# Altium schematic sheet Microcontroller.SchDoc
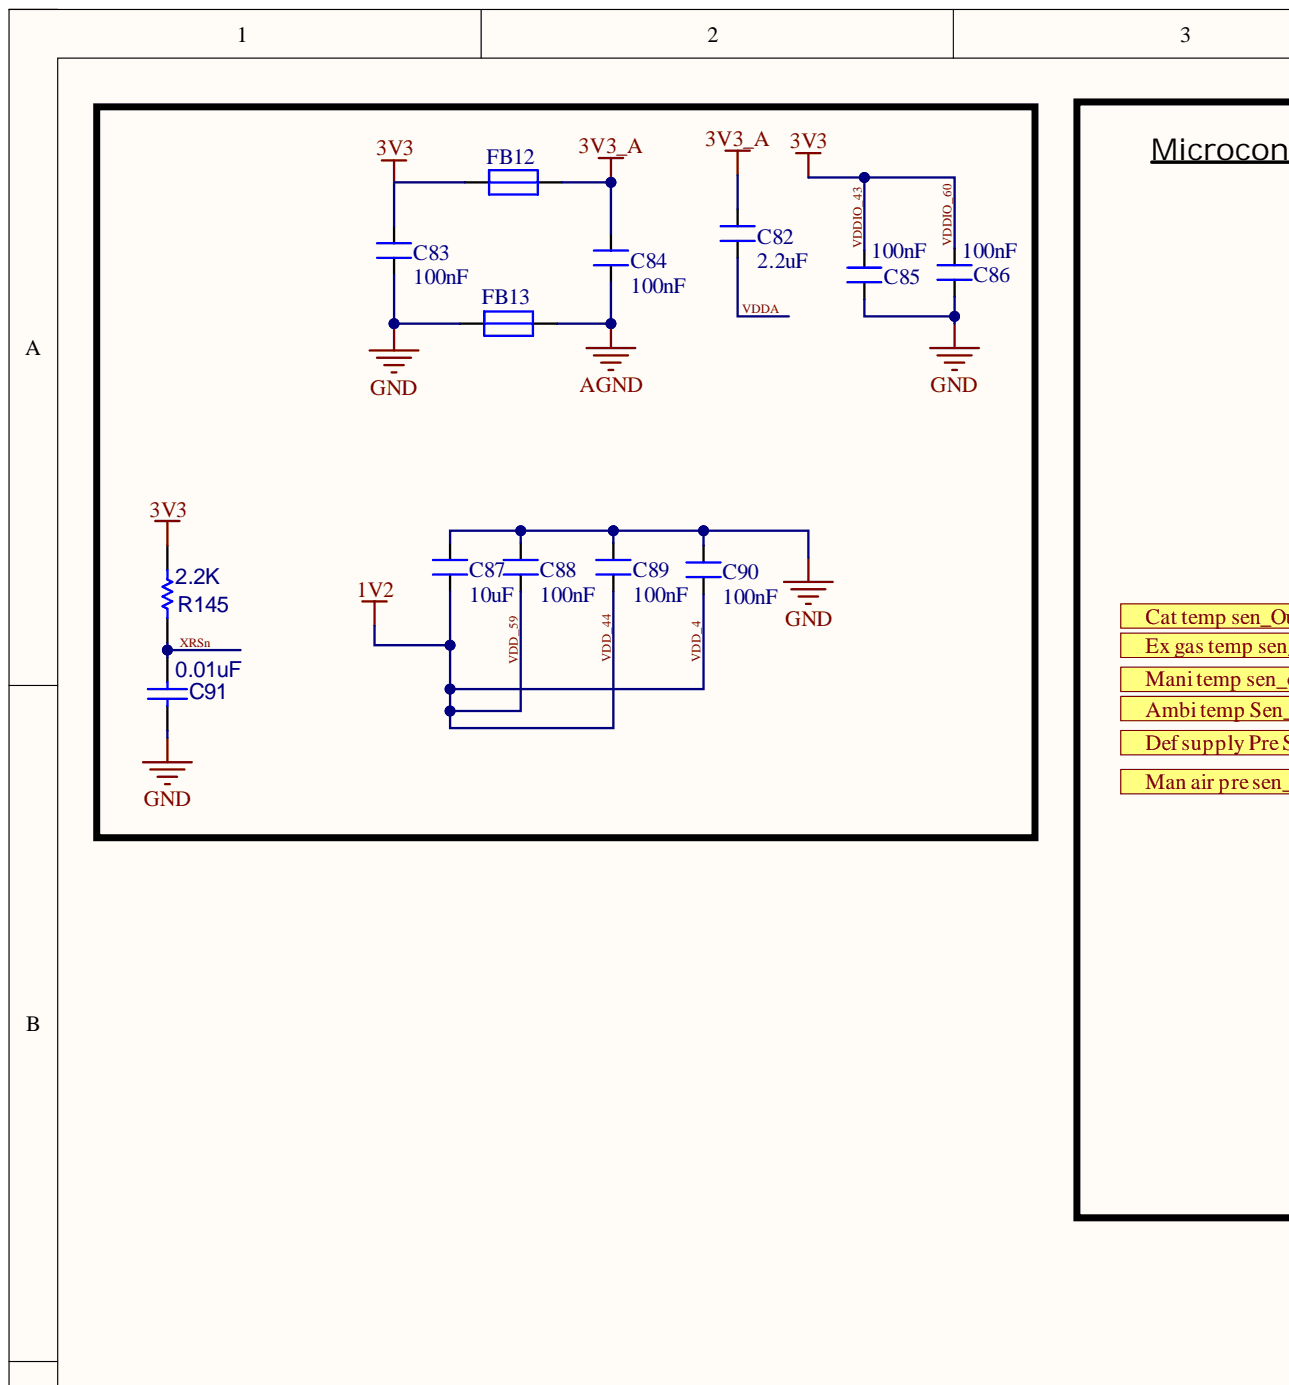
[diagram p0: Microcontroller.SchDoc, top left]
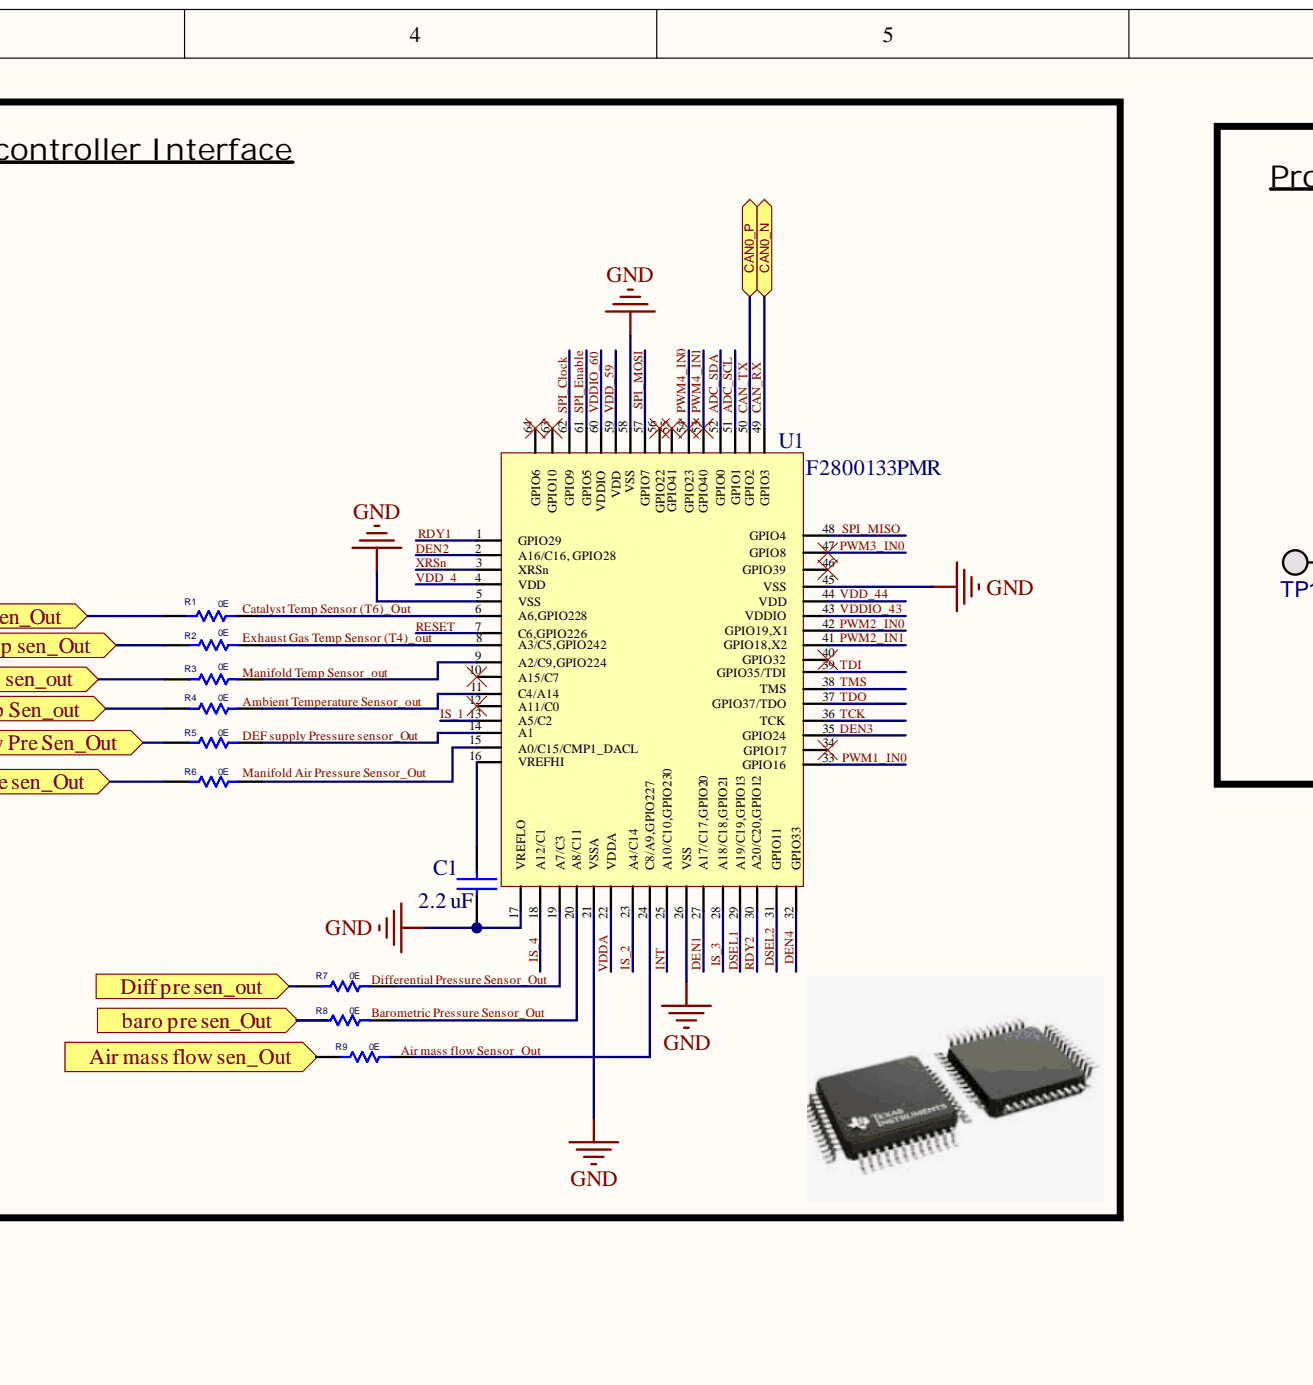
[diagram p1: Microcontroller.SchDoc, top centre]
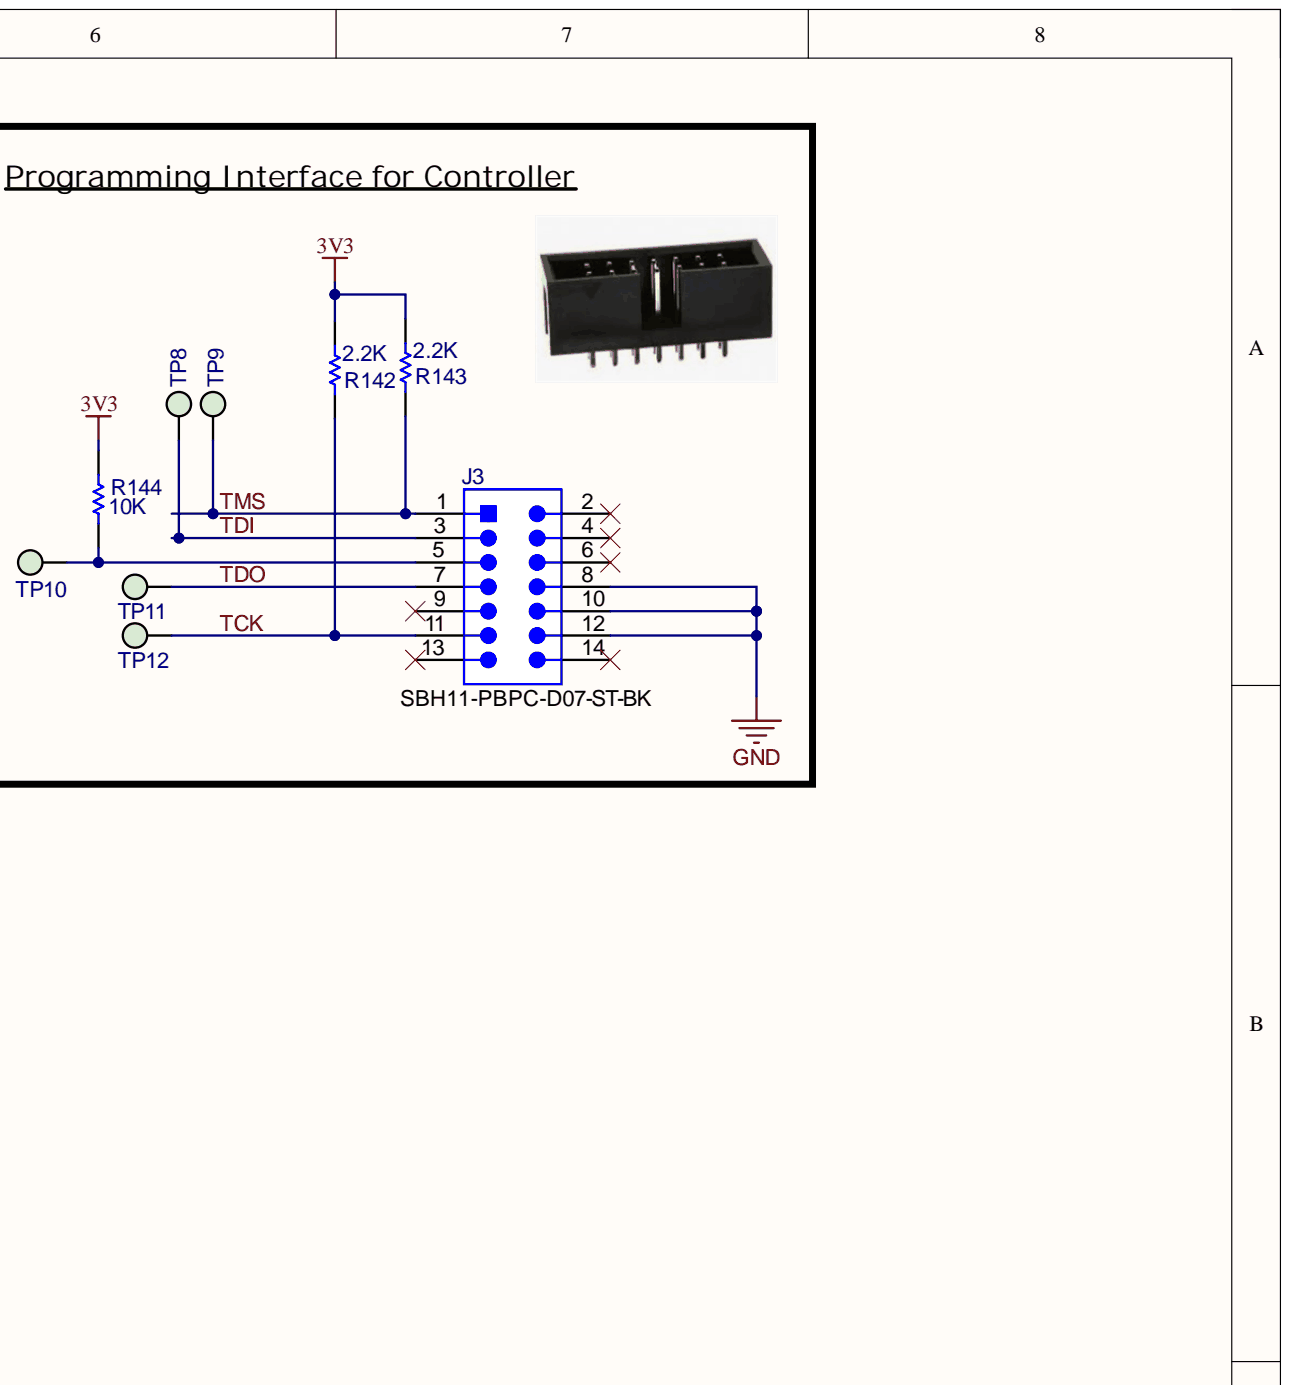
[diagram p2: Microcontroller.SchDoc, top right]
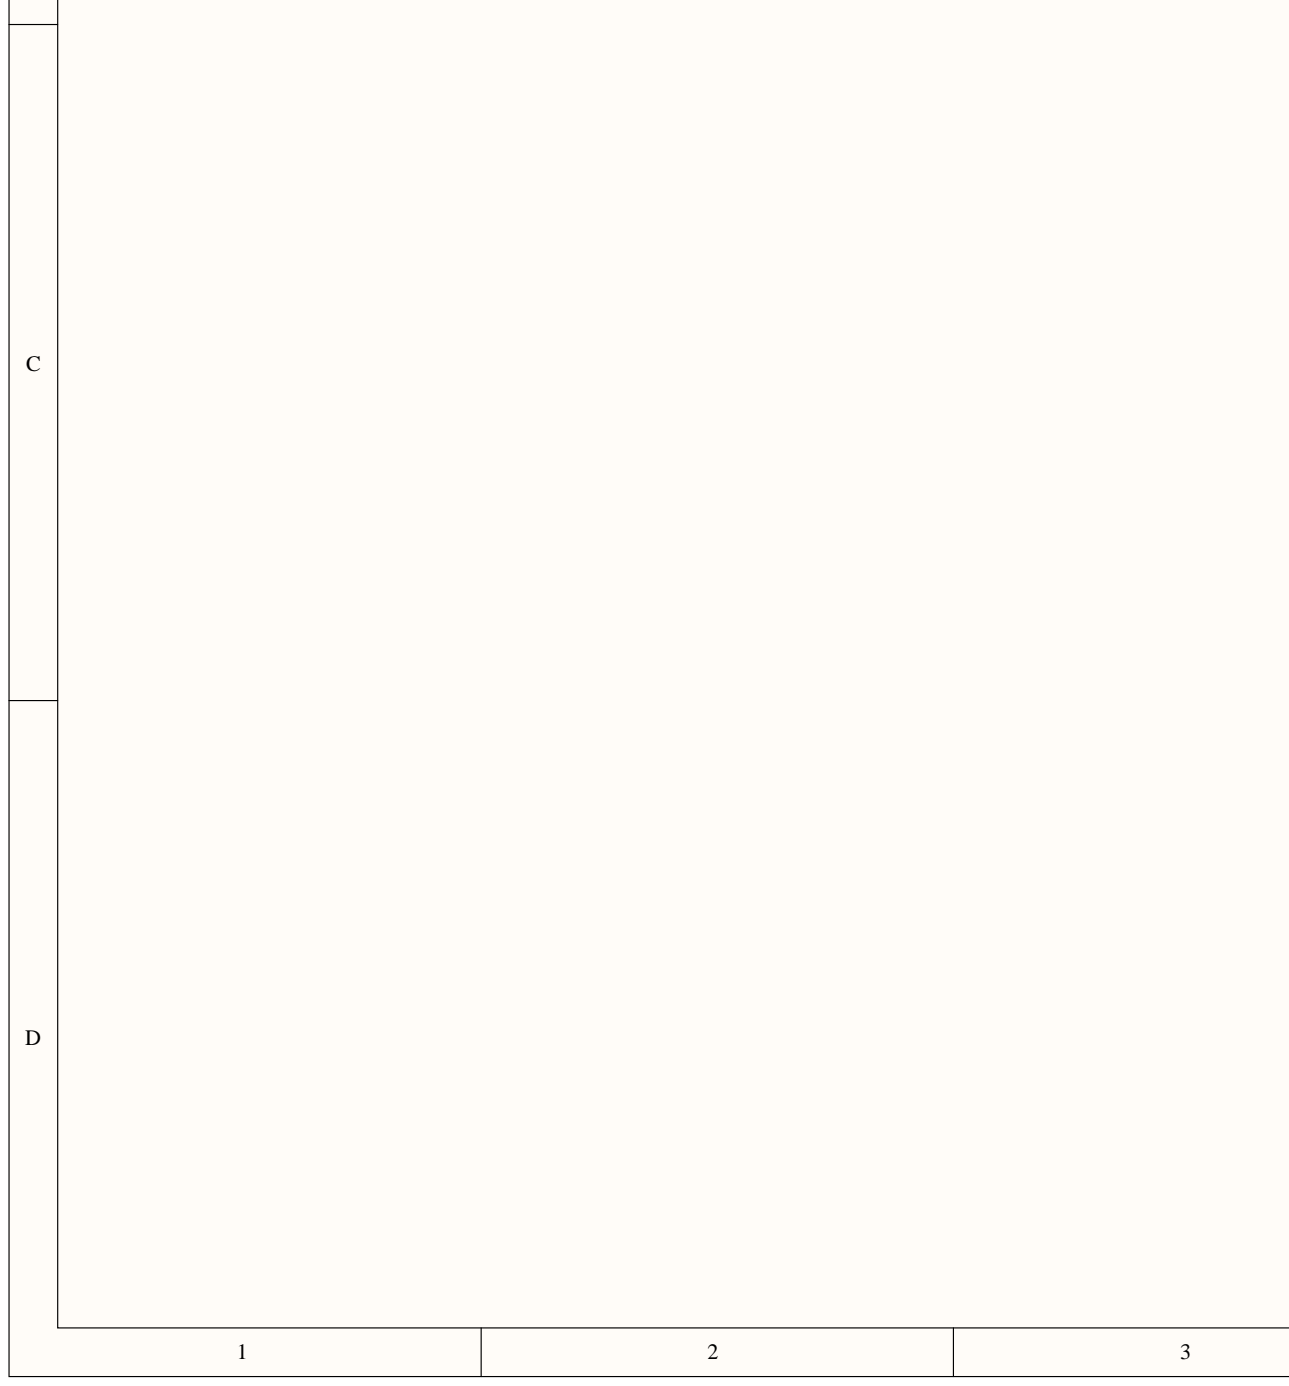
[diagram p3: Microcontroller.SchDoc, bottom left]
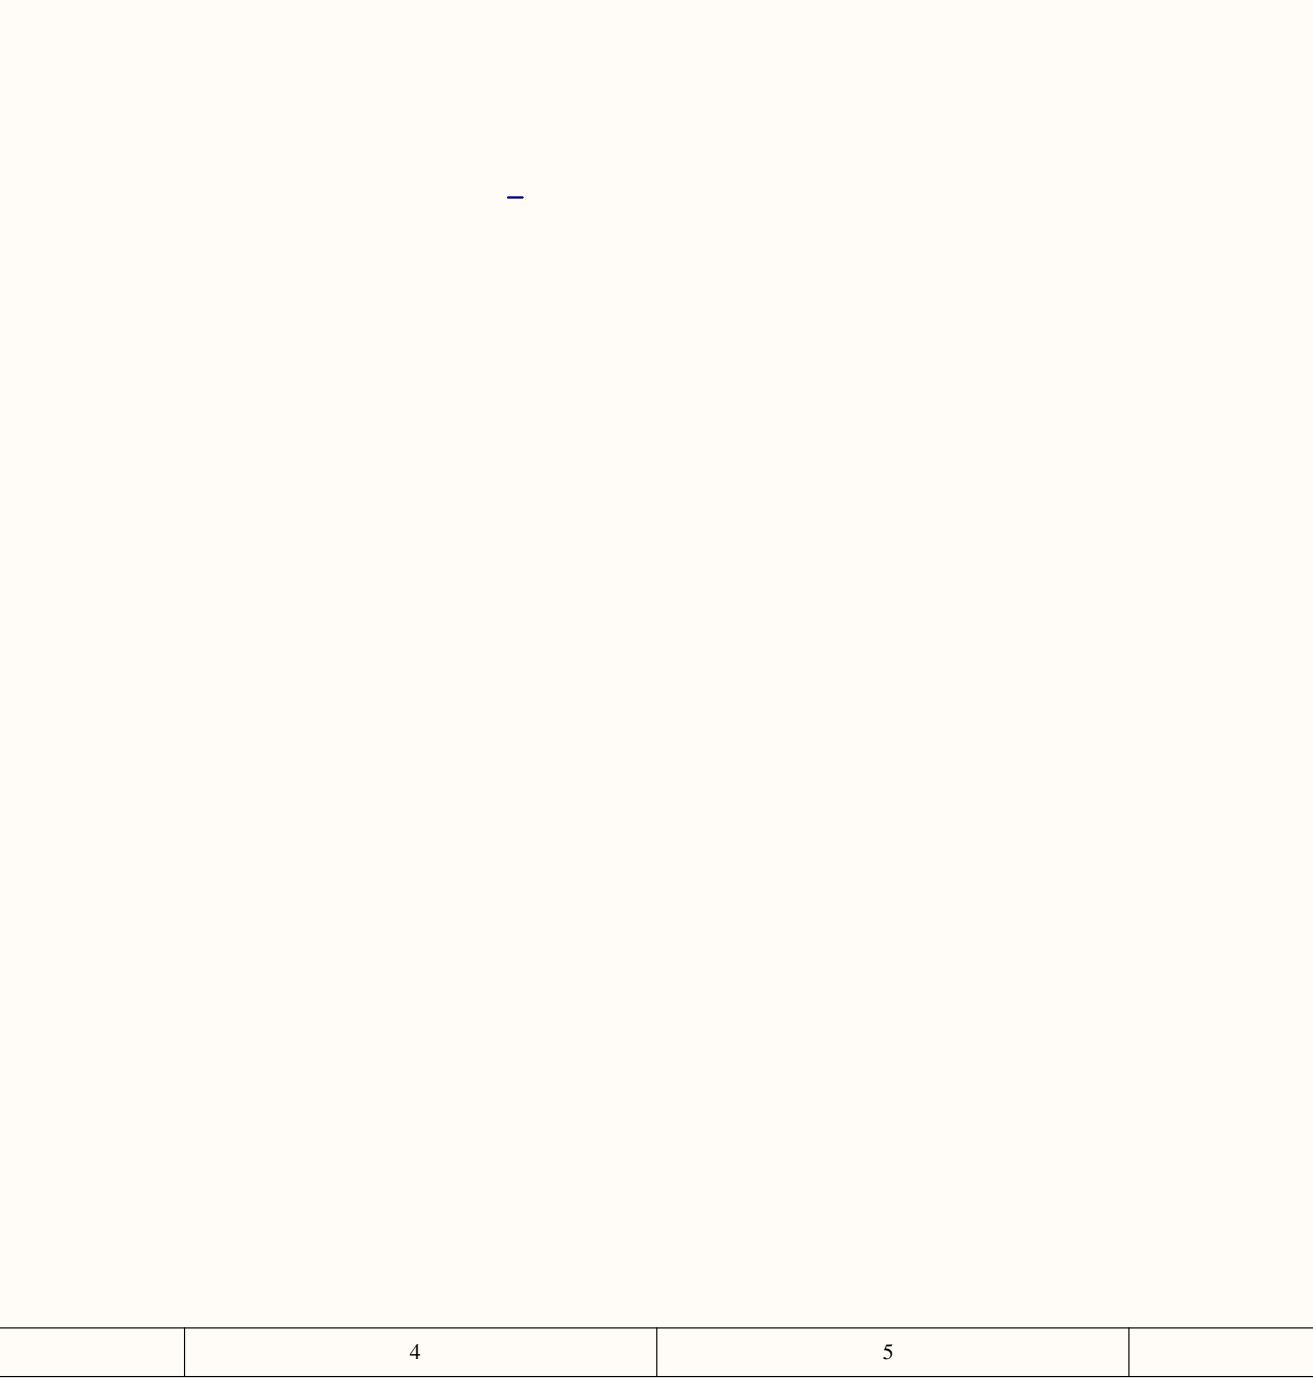
[diagram p4: Microcontroller.SchDoc, bottom centre]
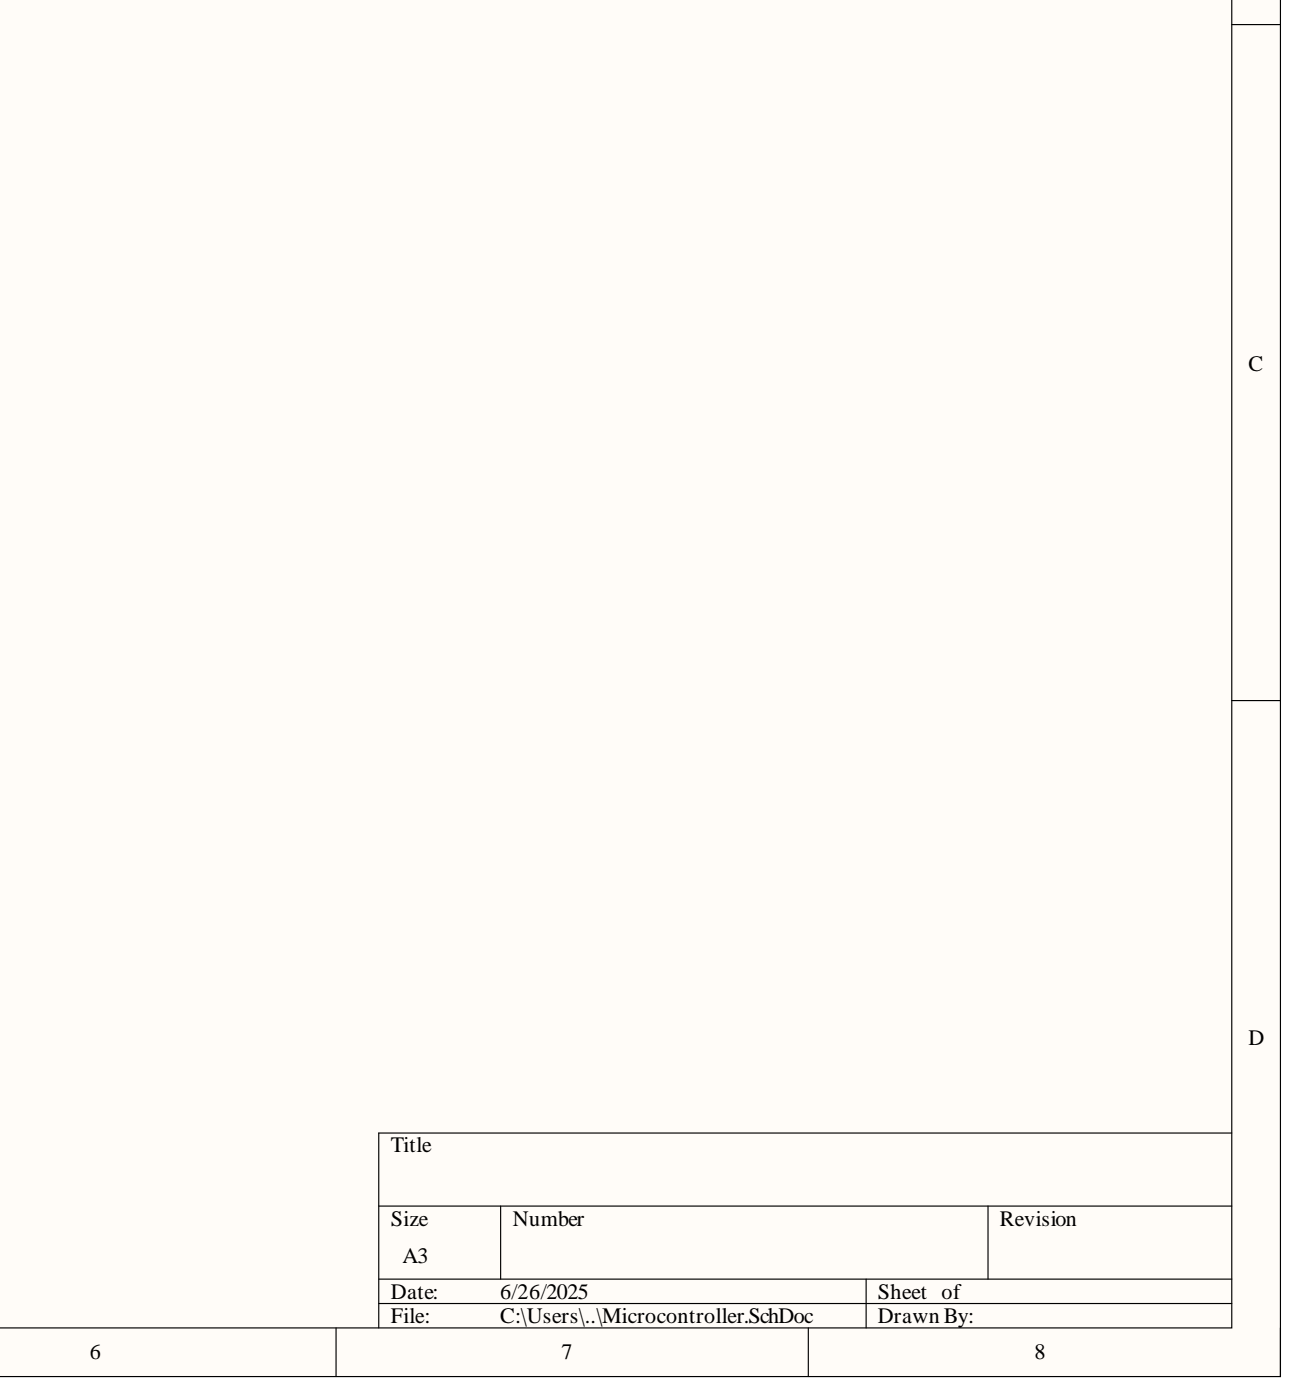
[diagram p5: Microcontroller.SchDoc, bottom right]

## Parts
C1  2.2 uF  [CAP-0805]
C82  2.2uF  [CAP_0603]
C83  100nF  [CAP_0201]
C84  100nF  [CAP_0201]
C85  100nF  [CAP_0402]
C86  100nF  [CAP_0402]
C87  10uF  [CAP_0603]
C88  100nF  [CAP_0402]
C89  100nF  [CAP_0402]
C90  100nF  [CAP_0402]
C91  0.01uF  [CAP-0603]
FB12  MPZ1608S101ATAH0  [RES_0603]  (value not drawn)
FB13  MPZ1608S101ATAH0  [RES_0603]  (value not drawn)
J3  SBH11-PBPC-D07-ST-BK  [3M_N2514-6002-RB]
R1  0E  [RES-0603]
R2  0E  [RES-0603]
R3  0E  [RES-0603]
R4  0E  [RES-0603]
R5  0E  [RES-0603]
R6  0E  [RES-0603]
R7  0E  [RES-0603]
R8  0E  [RES-0603]
R9  0E  [RES-0603]
R142  2.2K  [RES-0603]
R143  2.2K  [RES-0603]
R144  10K  [RES-0603]
R145  2.2K  [RES-0603]
TP8  Test Point  [TestPoint]  (value not drawn)
TP9  Test Point  [TestPoint]  (value not drawn)
TP10  Test Point  [TestPoint]  (value not drawn)
TP11  Test Point  [TestPoint]  (value not drawn)
TP12  Test Point  [TestPoint]  (value not drawn)
U1  F2800133PMR  [F2800133PMR(1)]

## Ports
Air mass flow sen_Out, Ambi temp Sen_out, baro pre sen_Out, CAN0_N, CAN0_P, Cat temp sen_Out, Def supply Pre Sen_Out, Diff pre sen_out, Ex gas temp sen_Out, Man air pre sen_Out, Mani temp sen_out

## Nets
3V3_A: C82.2 C84.2 FB12.2
AGND: C84.1 FB13.2
GND: C1.2 C83.1 C85.1 C86.1 C87.2 C88.2 C89.2 C90.2 C91.1 FB13.1 J3.8 J3.10 J3.12 U1.5(VSS) U1.17(VREFLO) U1.21(VSSA) U1.26(VSS) U1.45(VSS) U1.58(VSS)
ADC_SCL: U1.51(GPIO1)
ADC_SDA: U1.52(GPIO0)
Ambi temp Sen_out: R4.1 ; port Ambi temp Sen_out
CAN_RX: U1.49(GPIO3) ; port CAN0_N
CAN_TX: U1.50(GPIO2) ; port CAN0_P
Def supply Pre Sen_Out: R5.1 ; port Def supply Pre Sen_Out
DEN1: U1.27(A17/C17,GPIO20)
DEN2: U1.2(A16/C16,_GPIO28)
DEN3: U1.35(GPIO24)
DEN4: U1.32(GPIO33)
Diff pre sen_out: R7.1 ; port Diff pre sen_out
DSEL1: U1.29(A19/C19,GPIO13)
DSEL2: U1.31(GPIO11)
Ex gas temp sen_Out: R2.1 ; port Ex gas temp sen_Out
INT: U1.25(A10/C10,GPIO230)
IS_1: U1.13(A5/C2)
IS_2: U1.23(A4/C14)
IS_3: U1.28(A18/C18,GPIO21)
IS_4: U1.18(A12/C1)
Man air pre sen_Out: R6.1 ; port Man air pre sen_Out
Mani temp sen_out: R3.1 ; port Mani temp sen_out
PWM1_IN0: U1.33(GPIO16)
PWM2_IN0: U1.42(GPIO19,X1)
PWM2_IN1: U1.41(GPIO18,X2)
PWM3_IN0: U1.47(GPIO8)
PWM4_IN0: U1.54(GPIO23)
PWM4_IN1: U1.53(GPIO40)
RDY1: U1.1(GPIO29)
RDY2: U1.30(A20/C20,GPIO12)
RESET: U1.7(C6,GPIO226)
SPI_Clock: U1.62(GPIO9)
SPI_Enable: U1.61(GPIO5)
SPI_MISO: U1.48(GPIO4)
SPI_MOSI: U1.57(GPIO7)
TCK: J3.11 R142.1 TP12.1 U1.36(TCK)
TDI: J3.3 TP8.1 U1.39(GPIO35/TDI)
TDO: J3.7 TP11.1 U1.37(GPIO37/TDO)
TMS: J3.1 R143.1 TP9.1 U1.38(TMS)
VDD_4: C87.1 C88.1 C89.1 C90.1 U1.4(VDD) U1.44(VDD) U1.59(VDD)
VDDA: C82.1 U1.22(VDDA)
VDDIO_43: C83.2 C85.2 C86.2 R142.2 R143.2 R144.2 R145.2 U1.43(VDDIO) U1.60(VDDIO)
XRSn: C91.2 R145.1 U1.3(XRSn)
NetC1_2: C1.2 U1.16(VREFHI)
NetJ3_5: J3.5 R144.1 TP10.1
NetR1_2: R1.2 U1.6(A6,GPIO228)
NetR2_2: R2.2 U1.8(A3/C5,GPIO242)
NetR3_2: R3.2 U1.9(A2/C9,GPIO224)
NetR4_2: R4.2 U1.11(C4/A14)
NetR5_2: R5.2 U1.14(A1)
NetR6_2: R6.2 U1.15(A0/C15/CMP1_DACL)
NetR7_2: R7.2 U1.19(A7/C3)
NetR8_2: R8.2 U1.20(A8/C11)
NetR9_2: R9.2 U1.24(C8/A9,GPIO227)
Air mass flow Sensor_Out:
Ambient Temperature Sensor_out:
Barometric Pressure Sensor_Out:
Catalyst Temp Sensor (T6)_Out:
DEF supply Pressure sensor_Out:
Differential Pressure Sensor_Out:
Exhaust Gas Temp Sensor (T4)_out:
Manifold Air Pressure Sensor_Out:
Manifold Temp Sensor_out:
N00001: ; port Cat temp sen_Out
N00002: ; port baro pre sen_Out
N00003: ; port Air mass flow sen_Out

Not connected: FB12 1; J3 2 4 6 9 13 14; R1 1; R8 1; R9 1; U1 10(A15/C7) 12(A11/C0) 34(GPIO17) 40(GPIO32) 46(GPIO39) 55(GPIO41) 56(GPIO22) 63(GPIO10) 64(GPIO6)

## Library data of the parts
C1 = CAP_2.2 µF ±5% 16V Ceramic Capacitor X7R 0805: 2.2 µF ±5% 16V Ceramic Capacitor X7R 0805 (2012 Metric), C0805C225J4RECTU; Component Kind: Standard; Datasheet: https://content.kemet.com/datasheets/KEM_C1090_X7R_ESD.pdf; Library Name: GENSET CONTROLLER BETA V1.01.SCHLIB
C82 = CAP_2.2uF-10V-10%-0603: 2.2µF ±10% 16V Ceramic Capacitor X5R 0603 (1608 Metric); Manufacturer: KEMET; Manufacturer Part Number: C0603C225K4PACTU; Case/Package: 0603; Component Kind: Standard; Supplier-1: Digikey; Library Name: EsteemPCB_COB_Course_intlib.IntLib
C83 C84 = CAP_100nF-25V-10%-0201: 0.1µF ±10% 25V Ceramic Capacitor X5R 0201 (0603 Metric); Manufacturer: TDK Corporation; Manufacturer Part Number: C0603X5R1E104K030BB; Case/Package: 0201; Component Kind: Standard; Supplier-1: Digikey; Library Name: EsteemPCB_COB_Course_intlib.IntLib
C85 C86 C88-C90 = CAP_100nF-25V-10%-0402: 0.1µF ±10% 25V Ceramic Capacitor X7R 0402 (1005 Metric); Manufacturer: Walsin Technology Corporation; Manufacturer Part Number: 0402B104K250CT; Case/Package: 0402; Component Kind: Standard; Supplier-1: Digikey; Library Name: EsteemPCB_COB_Course_intlib.IntLib
C87 = CAP_10uF-25V-10%-0603: 10µF ±10% 25V Ceramic Capacitor X5R 0603 (1608 Metric); Manufacturer: Murata Electronics; Manufacturer Part Number: GRM188R61E106KA73D; Case/Package: 0603; Component Kind: Standard; Supplier-1: Digikey; Library Name: EsteemPCB_COB_Course_intlib.IntLib
C91 = CAP_16: CAP CER 10000PF 25V X7R 0603; Manufacturer: YAGEO; Part Number: CC0603JRX7R8BB103; Case-EIA: 0603; Tolerance: ±1%; Voltage: 35V; Component Kind: Standard; Package: 0603; Rated Voltage: 25V; Technology: SMT; Value: 0.01uF; Library Name: GENSET CONTROLLER BETA V1.01.SCHLIB
FB12 FB13 = FB_100R-3A-100Mhz-0603: Ferrite Beads 100ohms 3A 30mOhms 0603 Ferrite Chip; Manufacturer: TDK; Case/Package: 0603; Component Kind: Standard; Mnaufacturer Part Number: MPZ1608S101ATAH0; Supplier-1: Digikey; Library Name: EsteemPCB_COB_Course_intlib.IntLib
J3 = 0015912140: CONN HEADER VERT 14POS 2.54MM; Manufacturer: Sullins Connector Solutions; Component Kind: Standard; Library Name: Connectors.IntLib; PackageReference: Header, 100 mil, 7x2, SMT; Part Number: SBH11-PBPC-D07-ST-BK
R1-R9 = RES-2: RES SMD 0 OHM JUMPER 1/10W 0603; Manufacturer: YAGEO; Part Number: AC0603FR-070RL; Power: 0.1W; Tolerance: ±1%; Component Kind: Standard; Library Name: Daughter_board_SE3_V1.SCHLIB; Package: 0603; Value: 0E
R142 R143 R145 = Resistor_2: RES SMD 2.2K OHM 1% 1/10W 0603; Manufacturer: YAGEO; Part Number: AF0603FR-072K2L; Component Kind: Standard; Package: 0603; Value: 2.2K; Library Name: GENSET CONTROLLER BETA V1.01.SCHLIB
R144 = RES-2: RES SMD 10K OHM 1% 1/10W 0603; Manufacturer: YAGEO; Part Number: AC0603FR-0710KL; Power Rating: 100mW; Component Kind: Standard; Library Name: Daughter_board_SE3_V1.SCHLIB; Package: 0603; Tolerance: ±1%; Value: 10K
TP8-TP12 = (no symbol name): Test Point 0.3mm DIA; Component Kind: Standard
U1 = F2800133PMR: C2000™ 32-bit MCU with 120 MHz, 64-KB flash, FPU and TMU; Component Kind: Standard; Library Name: F2800133PMR.SchLib
